# Altium schematic sheet Wemos.SchDoc
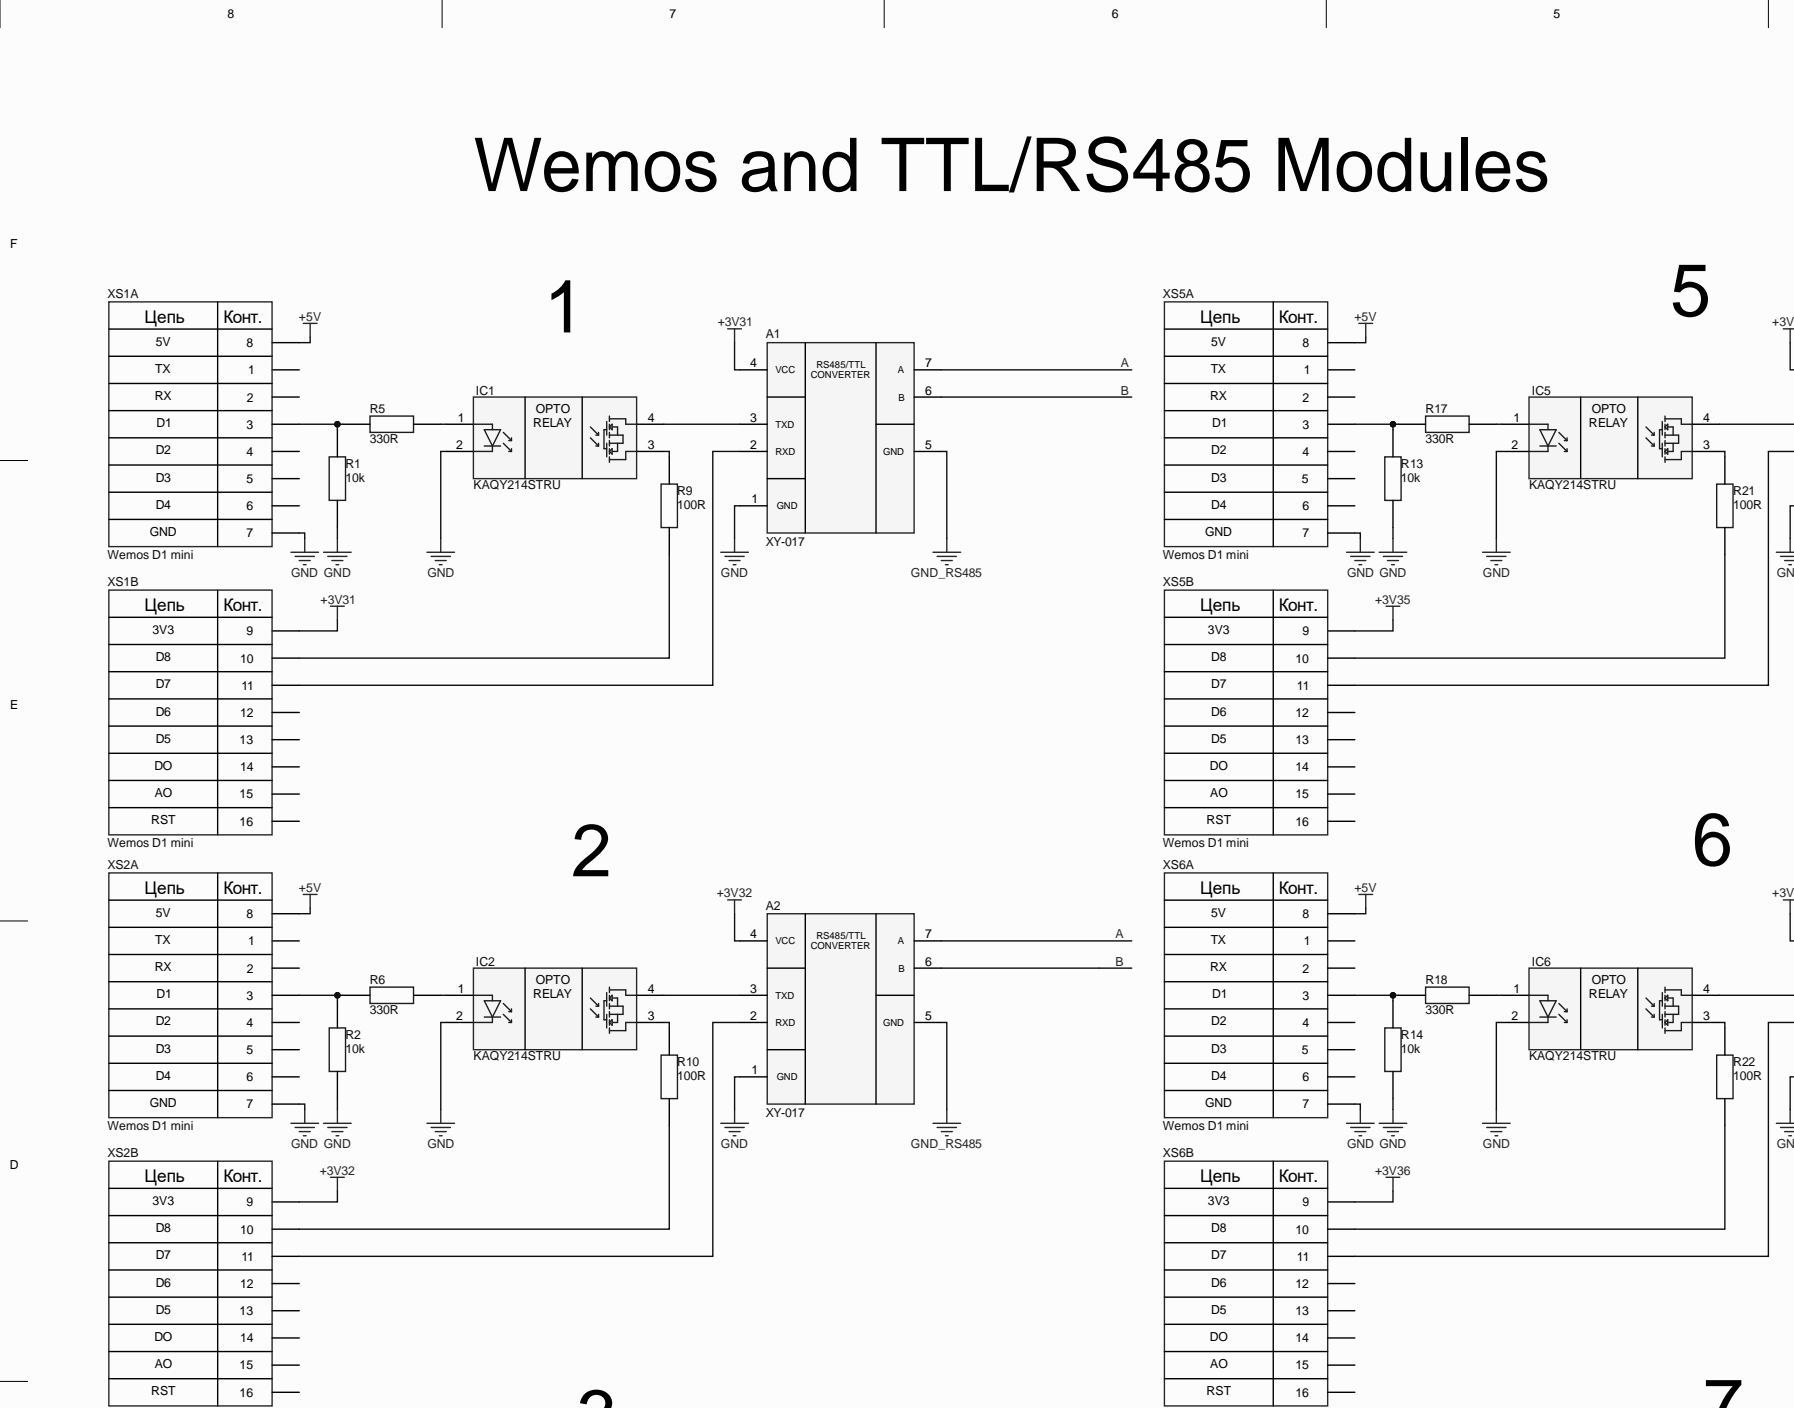
[diagram p0: Wemos.SchDoc, top left]
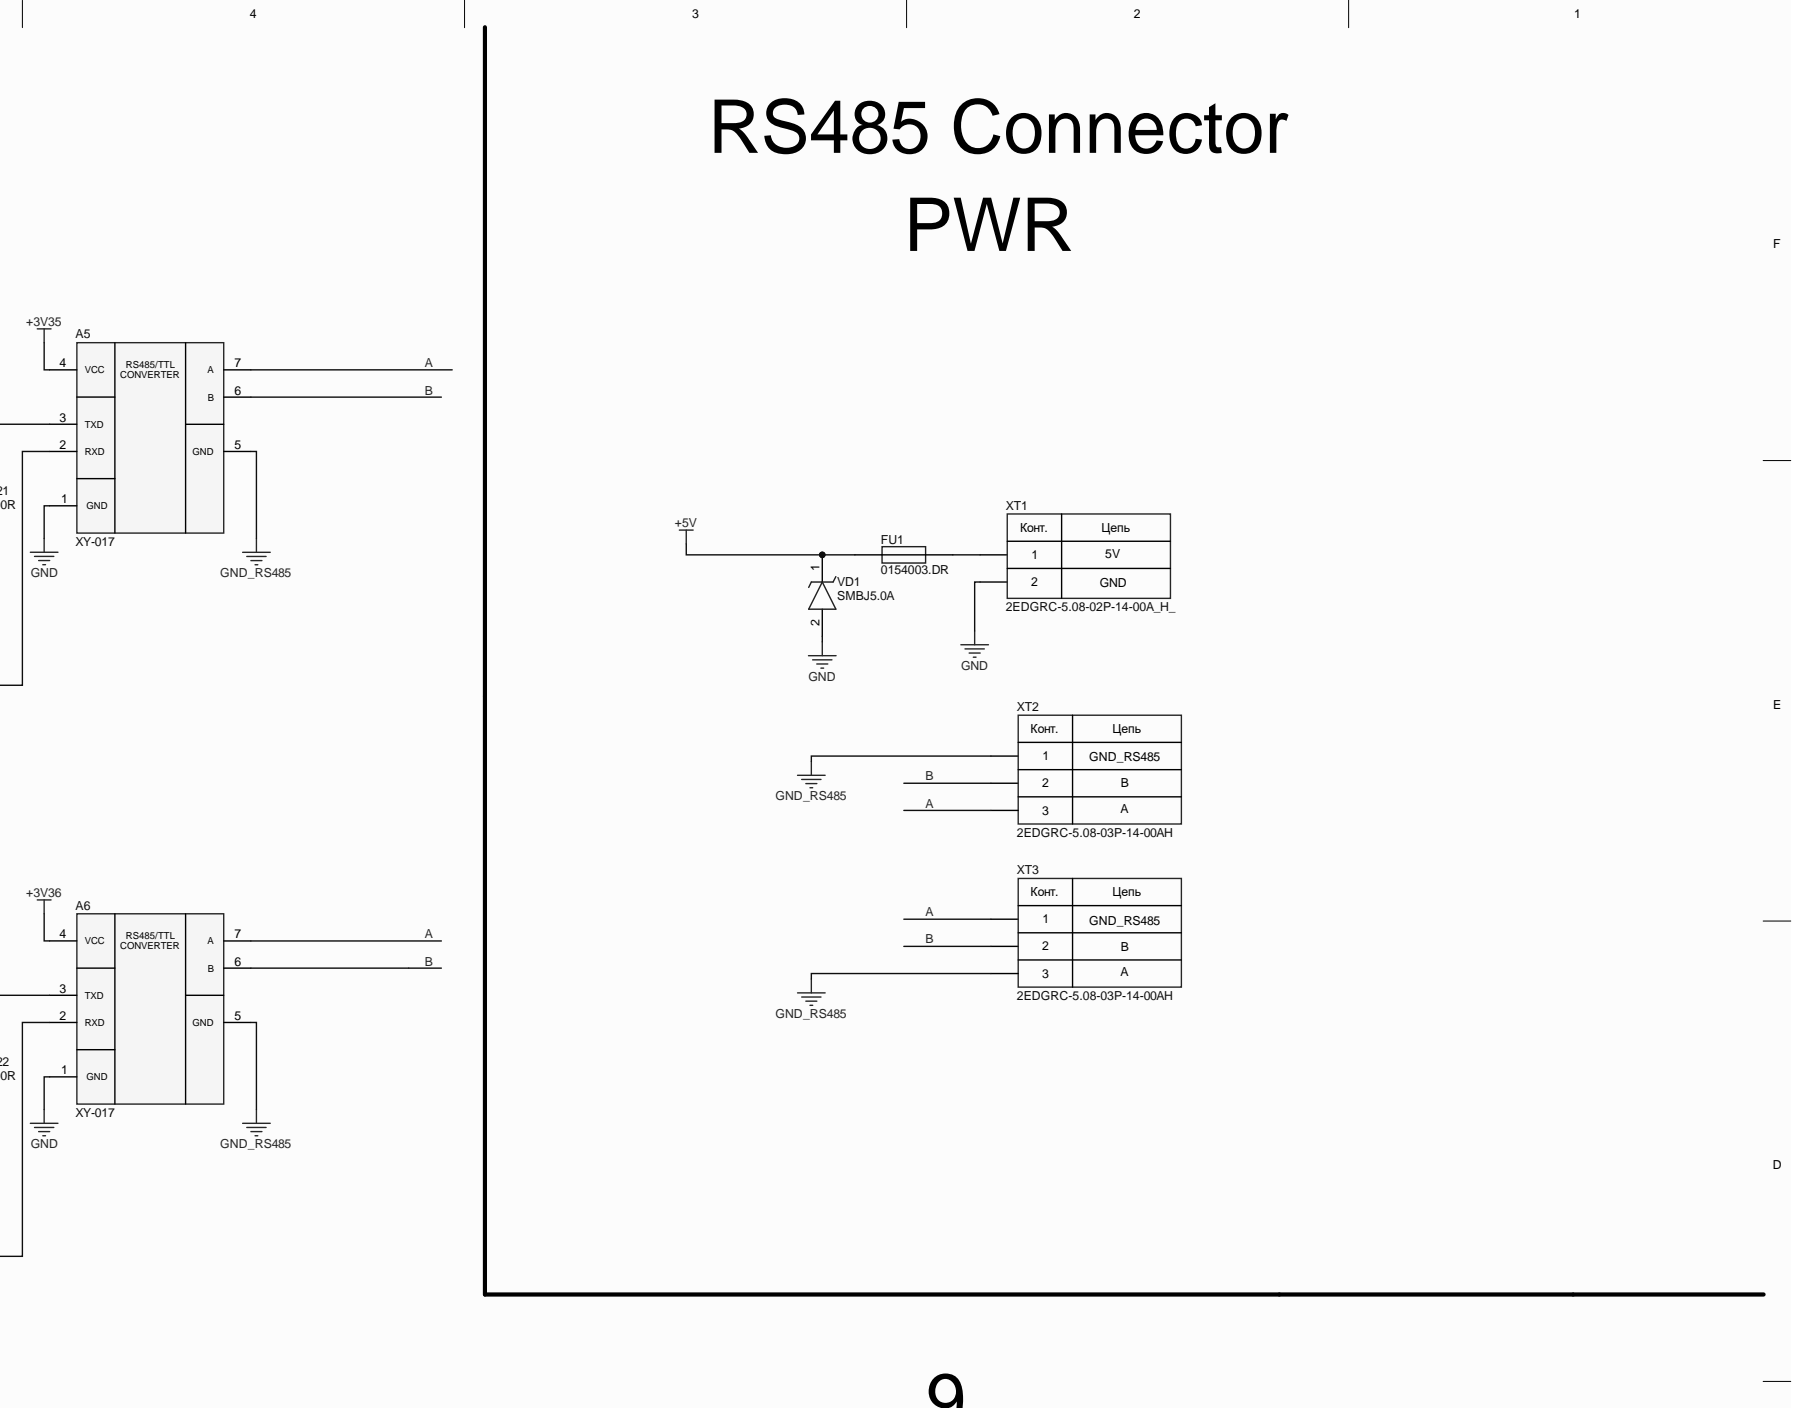
[diagram p1: Wemos.SchDoc, top right]
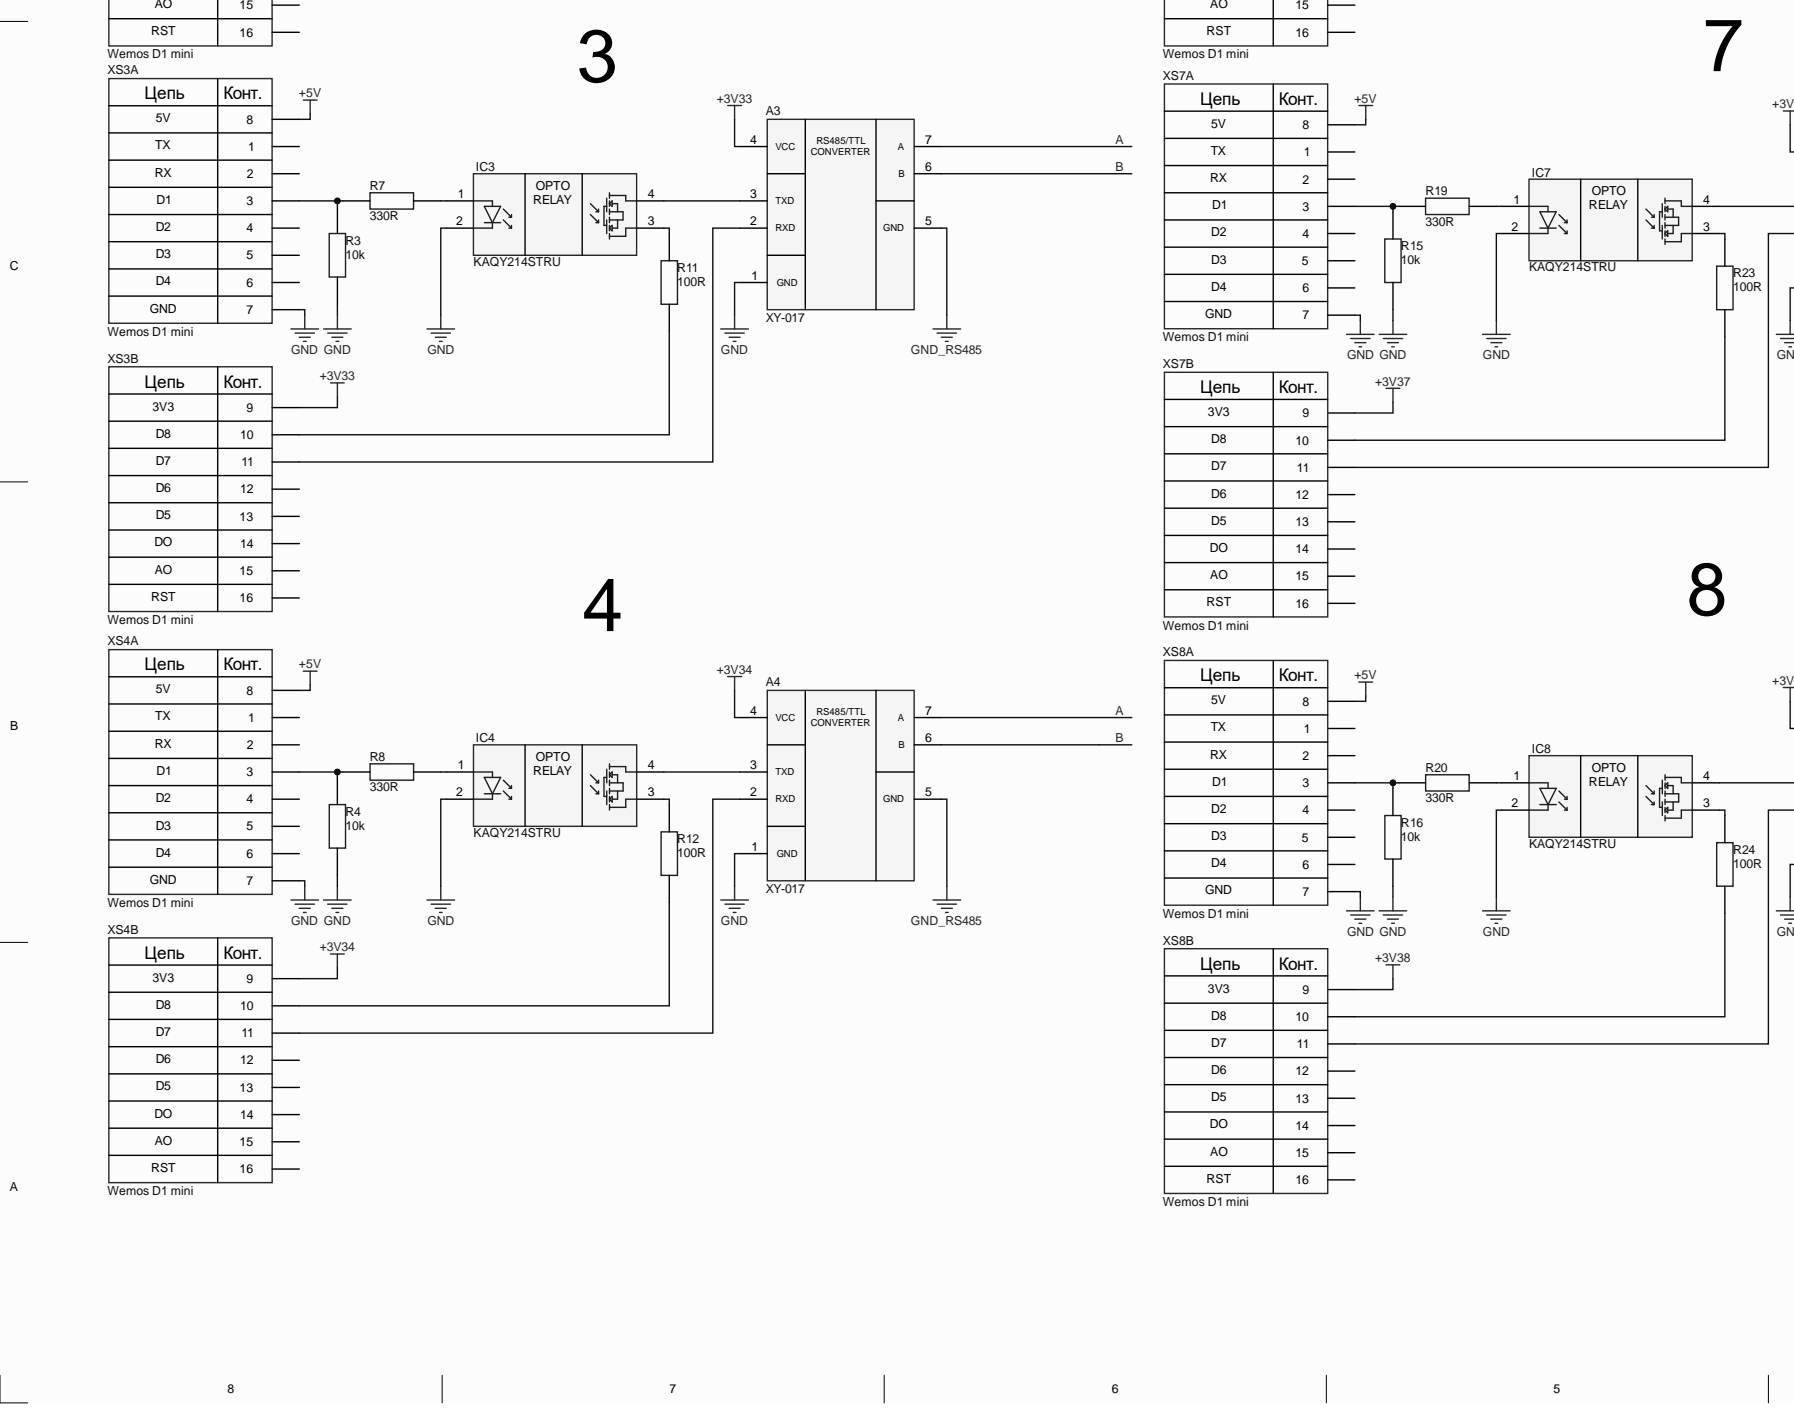
[diagram p2: Wemos.SchDoc, bottom left]
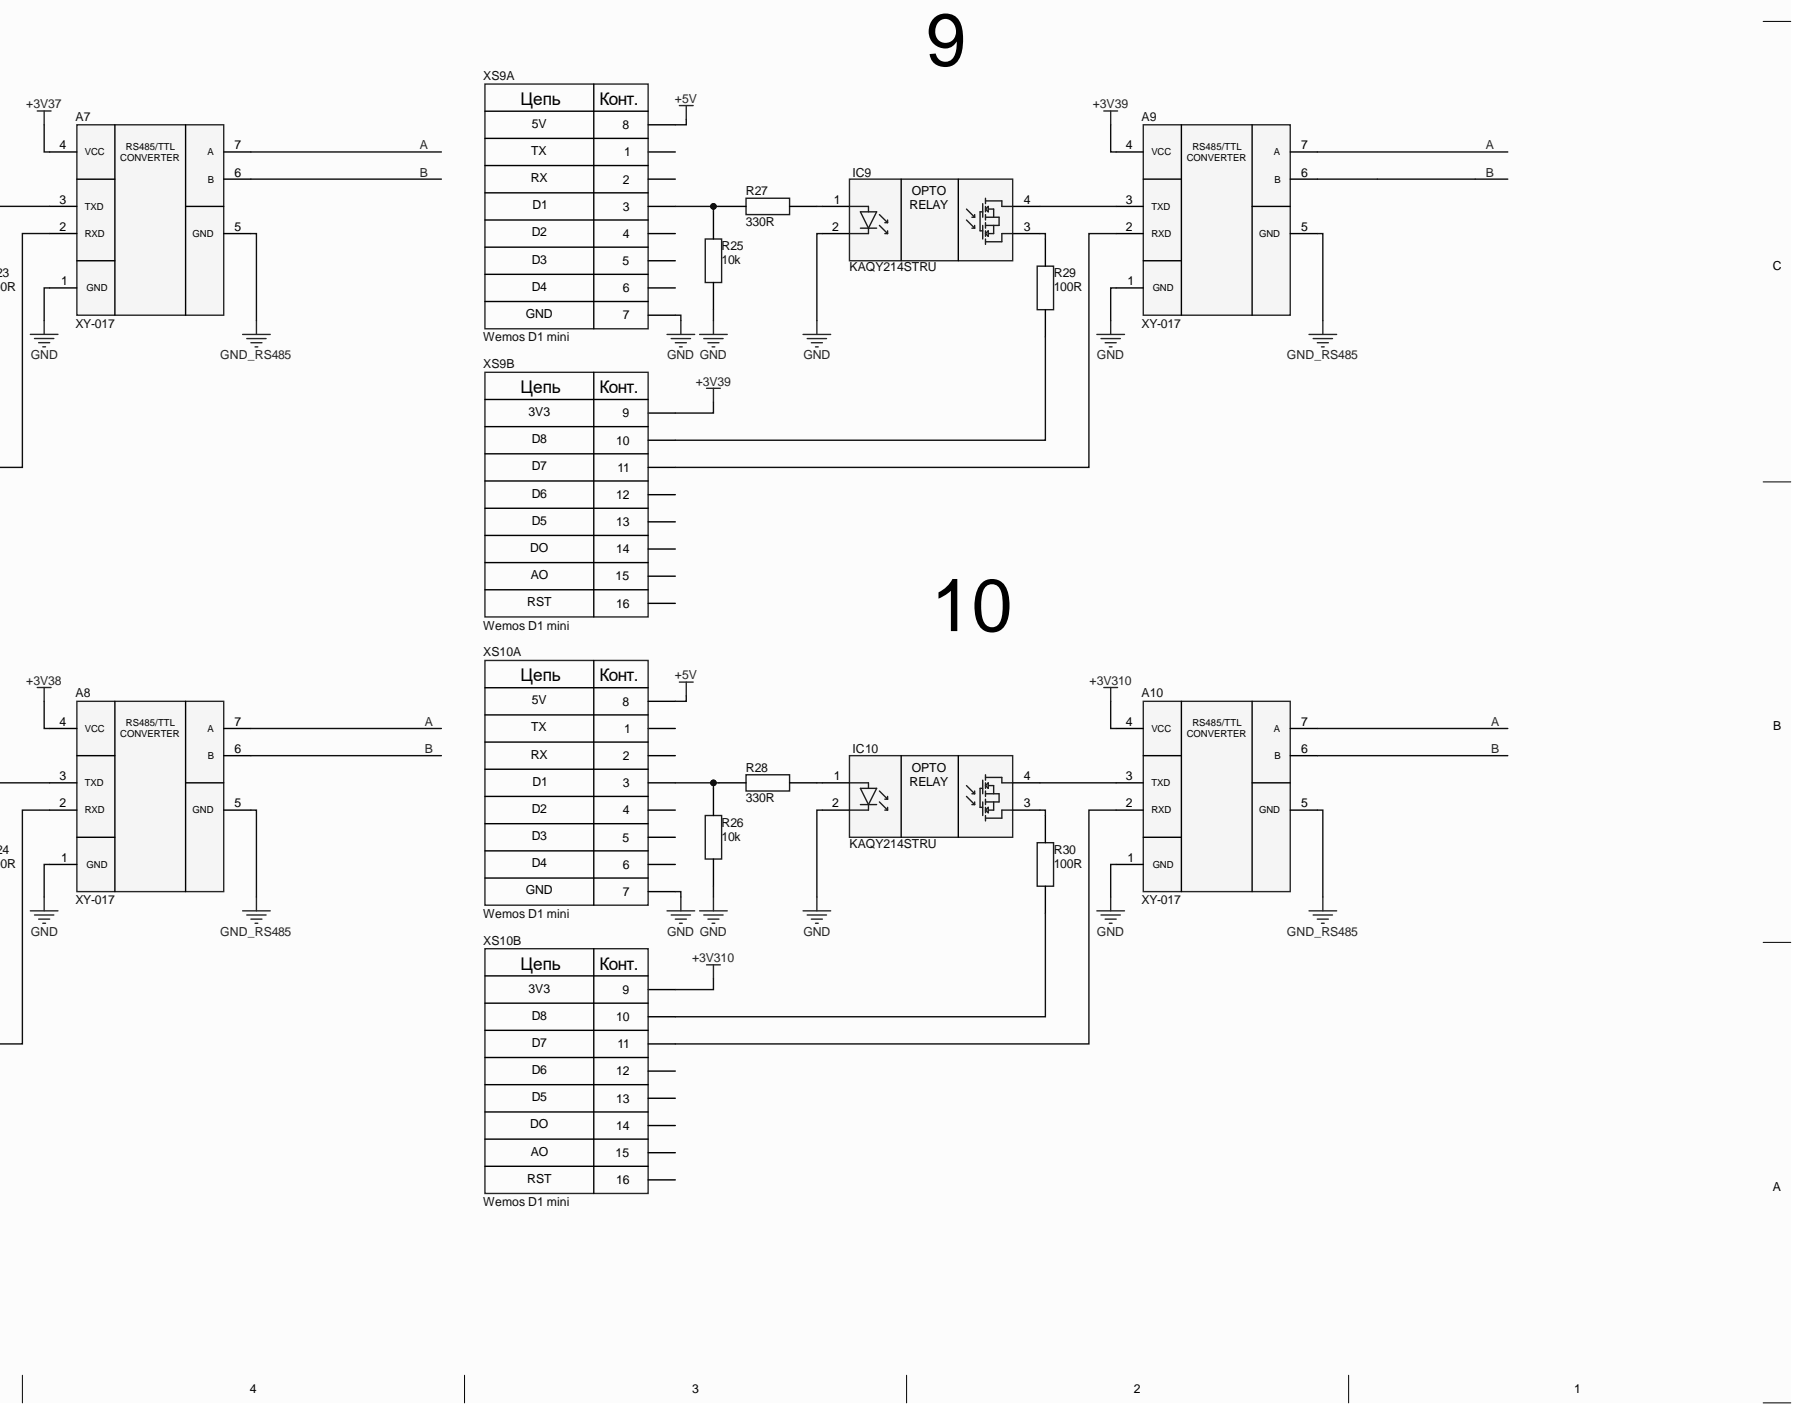
[diagram p3: Wemos.SchDoc, bottom right]

## Parts
A1  XY-017  [XY-017 RS485/TTL]
A2  XY-017  [XY-017 RS485/TTL]
A3  XY-017  [XY-017 RS485/TTL]
A4  XY-017  [XY-017 RS485/TTL]
A5  XY-017  [XY-017 RS485/TTL]
A6  XY-017  [XY-017 RS485/TTL]
A7  XY-017  [XY-017 RS485/TTL]
A8  XY-017  [XY-017 RS485/TTL]
A9  XY-017  [XY-017 RS485/TTL]
A10  XY-017  [XY-017 RS485/TTL]
FU1  0154003.DR  [0154.250DR]
IC1  KAQY214STRU  [SOP-4]
IC2  KAQY214STRU  [SOP-4]
IC3  KAQY214STRU  [SOP-4]
IC4  KAQY214STRU  [SOP-4]
IC5  KAQY214STRU  [SOP-4]
IC6  KAQY214STRU  [SOP-4]
IC7  KAQY214STRU  [SOP-4]
IC8  KAQY214STRU  [SOP-4]
IC9  KAQY214STRU  [SOP-4]
IC10  KAQY214STRU  [SOP-4]
R1  10k  [RES_0603]
R2  10k  [RES_0603]
R3  10k  [RES_0603]
R4  10k  [RES_0603]
R5  330R  [RES_0603]
R6  330R  [RES_0603]
R7  330R  [RES_0603]
R8  330R  [RES_0603]
R9  100R  [RES_0603]
R10  100R  [RES_0603]
R11  100R  [RES_0603]
R12  100R  [RES_0603]
R13  10k  [RES_0603]
R14  10k  [RES_0603]
R15  10k  [RES_0603]
R16  10k  [RES_0603]
R17  330R  [RES_0603]
R18  330R  [RES_0603]
R19  330R  [RES_0603]
R20  330R  [RES_0603]
R21  100R  [RES_0603]
R22  100R  [RES_0603]
R23  100R  [RES_0603]
R24  100R  [RES_0603]
R25  10k  [RES_0603]
R26  10k  [RES_0603]
R27  330R  [RES_0603]
R28  330R  [RES_0603]
R29  100R  [RES_0603]
R30  100R  [RES_0603]
VD1  SMBJ5.0A  [SMB/DOT-214AA]
XS1  Wemos D1 mini  [Wemos D1 mini]  (parts A,B)
XS2  Wemos D1 mini  [Wemos D1 mini]  (parts A,B)
XS3  Wemos D1 mini  [Wemos D1 mini]  (parts A,B)
XS4  Wemos D1 mini  [Wemos D1 mini]  (parts A,B)
XS5  Wemos D1 mini  [Wemos D1 mini]  (parts A,B)
XS6  Wemos D1 mini  [Wemos D1 mini]  (parts A,B)
XS7  Wemos D1 mini  [Wemos D1 mini]  (parts A,B)
XS8  Wemos D1 mini  [Wemos D1 mini]  (parts A,B)
XS9  Wemos D1 mini  [Wemos D1 mini]  (parts A,B)
XS10  Wemos D1 mini  [Wemos D1 mini]  (parts A,B)
XT1  2EDGRC-5.08-02P-14-00A_H_  [2EDGRC-5.0-02P-14-00AH]
XT2  2EDGRС-5.08-03P-14-00AH  [2EDGRС-5.08-03P-14-00AH]
XT3  2EDGRС-5.08-03P-14-00AH  [2EDGRС-5.08-03P-14-00AH]

## Nets
+3V31: A1.4(VCC) XS1.9
+3V32: A2.4(VCC) XS2.9
+3V33: A3.4(VCC) XS3.9
+3V34: A4.4(VCC) XS4.9
+3V35: A5.4(VCC) XS5.9
+3V36: A6.4(VCC) XS6.9
+3V37: A7.4(VCC) XS7.9
+3V38: A8.4(VCC) XS8.9
+3V39: A9.4(VCC) XS9.9
+3V310: A10.4(VCC) XS10.9
+5V: FU1.1 VD1.1 XS1.8 XS2.8 XS3.8 XS4.8 XS5.8 XS6.8 XS7.8 XS8.8 XS9.8 XS10.8
GND: A1.1(GND) A2.1(GND) A3.1(GND) A4.1(GND) A5.1(GND) A6.1(GND) A7.1(GND) A8.1(GND) A9.1(GND) A10.1(GND) IC1.2 IC2.2 IC3.2 IC4.2 IC5.2 IC6.2 IC7.2 IC8.2 IC9.2 IC10.2 R1.1 R2.1 R3.1 R4.1 R13.1 R14.1 R15.1 R16.1 R25.1 R26.1 VD1.2 XS1.7 XS2.7 XS3.7 XS4.7 XS5.7 XS6.7 XS7.7 XS8.7 XS9.7 XS10.7 XT1.2
GND_RS485: A1.5(GND) A2.5(GND) A3.5(GND) A4.5(GND) A5.5(GND) A6.5(GND) A7.5(GND) A8.5(GND) A9.5(GND) A10.5(GND) XT2.1 XT3.3
A: A1.7(A) A2.7(A) A3.7(A) A4.7(A) A5.7(A) A6.7(A) A7.7(A) A8.7(A) A9.7(A) A10.7(A) XT2.3 XT3.1
B: A1.6(B) A2.6(B) A3.6(B) A4.6(B) A5.6(B) A6.6(B) A7.6(B) A8.6(B) A9.6(B) A10.6(B) XT2.2 XT3.2
NetA1_2: A1.2(RXD) XS1.11
NetA1_3: A1.3(TXD) IC1.4
NetA2_2: A2.2(RXD) XS2.11
NetA2_3: A2.3(TXD) IC2.4
NetA3_2: A3.2(RXD) XS3.11
NetA3_3: A3.3(TXD) IC3.4
NetA4_2: A4.2(RXD) XS4.11
NetA4_3: A4.3(TXD) IC4.4
NetA5_2: A5.2(RXD) XS5.11
NetA5_3: A5.3(TXD) IC5.4
NetA6_2: A6.2(RXD) XS6.11
NetA6_3: A6.3(TXD) IC6.4
NetA7_2: A7.2(RXD) XS7.11
NetA7_3: A7.3(TXD) IC7.4
NetA8_2: A8.2(RXD) XS8.11
NetA8_3: A8.3(TXD) IC8.4
NetA9_2: A9.2(RXD) XS9.11
NetA9_3: A9.3(TXD) IC9.4
NetA10_2: A10.2(RXD) XS10.11
NetA10_3: A10.3(TXD) IC10.4
NetFU1_2: FU1.2 XT1.1
NetIC1_1: IC1.1 R5.2
NetIC1_3: IC1.3 R9.2
NetIC2_1: IC2.1 R6.2
NetIC2_3: IC2.3 R10.2
NetIC3_1: IC3.1 R7.2
NetIC3_3: IC3.3 R11.2
NetIC4_1: IC4.1 R8.2
NetIC4_3: IC4.3 R12.2
NetIC5_1: IC5.1 R17.2
NetIC5_3: IC5.3 R21.2
NetIC6_1: IC6.1 R18.2
NetIC6_3: IC6.3 R22.2
NetIC7_1: IC7.1 R19.2
NetIC7_3: IC7.3 R23.2
NetIC8_1: IC8.1 R20.2
NetIC8_3: IC8.3 R24.2
NetIC9_1: IC9.1 R27.2
NetIC9_3: IC9.3 R29.2
NetIC10_1: IC10.1 R28.2
NetIC10_3: IC10.3 R30.2
NetR1_2: R1.2 R5.1 XS1.3
NetR2_2: R2.2 R6.1 XS2.3
NetR3_2: R3.2 R7.1 XS3.3
NetR4_2: R4.2 R8.1 XS4.3
NetR9_1: R9.1 XS1.10
NetR10_1: R10.1 XS2.10
NetR11_1: R11.1 XS3.10
NetR12_1: R12.1 XS4.10
NetR13_2: R13.2 R17.1 XS5.3
NetR14_2: R14.2 R18.1 XS6.3
NetR15_2: R15.2 R19.1 XS7.3
NetR16_2: R16.2 R20.1 XS8.3
NetR21_1: R21.1 XS5.10
NetR22_1: R22.1 XS6.10
NetR23_1: R23.1 XS7.10
NetR24_1: R24.1 XS8.10
NetR25_2: R25.2 R27.1 XS9.3
NetR26_2: R26.2 R28.1 XS10.3
NetR29_1: R29.1 XS9.10
NetR30_1: R30.1 XS10.10

Not connected: XS1 1 2 4 5 6 12 13 14 15 16; XS2 1 2 4 5 6 12 13 14 15 16; XS3 1 2 4 5 6 12 13 14 15 16; XS4 1 2 4 5 6 12 13 14 15 16; XS5 1 2 4 5 6 12 13 14 15 16; XS6 1 2 4 5 6 12 13 14 15 16; XS7 1 2 4 5 6 12 13 14 15 16; XS8 1 2 4 5 6 12 13 14 15 16; XS9 1 2 4 5 6 12 13 14 15 16; XS10 1 2 4 5 6 12 13 14 15 16

## Library data of the parts
A1-A10 = XY-017: RS485 to TTL converter; Current: 0.02A; Voltage: 5.5V; Case(Package): XY-017; Component Kind: Standard; ComponentLink1Description: Datasheet; HelpURL: https://www.chipdip.ru/product0/8021250854; Library Name: KIT_IC.SchLib; Manufacturer_ID: XY-017
FU1 = 0154003.DR: Fuse 3A; Case (Package): 2-SMD, Square End Block with Holder; Component Kind: Standard; ComponentLink1Description: Datasheet; ComponentLink1URL: file:///Z:/Shematic/Datasheet/0154003.pdf; Current: 3A; HelpURL: https://www.chipdip.ru/product0/8010909648; Library Name: KIT_Passive.SchLib; Manufacturer_ID: 0154003.DR; Value: 3A
IC1-IC10 = KAQY214STRU: SOLID STATE OPTO RELAY Normally open; Case (Package): SOP-4; Component Kind: Standard; HelpURL: https://www.promelec.ru/product/346490/; Library Name: KIT_IC.SchLib; Manufacturer_ID: KAQY214STRU
R1-R30 = Res_0603: Resistor; Case (Package): 0603; Component Kind: Standard; ComponentLink1Description: Datasheet; Library Name: KIT_Passive.SchLib
  R1-R4 R13-R16 R25 R26: Value: 10k
  R5-R8 R17-R20 R27 R28: Value: 330R
  R9-R12 R21-R24 R29 R30: Value: 100R
VD1 = SMBJ5.0A: TVS Diode Bidirectional; Case (Package): DO-214; Voltage: 6.4V; Component Kind: Standard; ComponentLink1Description: Datasheet; ComponentLink1URL: file://192.168.16.5/share/Shematic/Datasheet/SMBJ30A.pdf; HelpURL: https://sds.compel.ru/infosheet/YJ/SMBJ30A; Library Name: KIT_Active.SchLib; Manufacturer_ID: SMBJ5.0A
XS1-XS10 = Wemos D1 mini: Connectors; Number of contacts: 16; Pin pitch: 2.54mm; Component Kind: Standard; ComponentLink1Description: Datasheet; Library Name: KIT_Connectors.SchLib; Manufacturer_ID: Wemos D1 mini; Number of rows: 1
XT1 = 2EDGRC-5.08-02P-14-00A_H_: Connectors; Number of contacts: 2; Pin pitch: 5.08; Component Kind: Standard; ComponentLink1Description: Datasheet; ComponentLink1URL: file://192.168.16.5/share/Shematic/Datasheet/2EDGRC-5.08-02P-14-00A_H_.pdf; HelpURL: https://www.chipdip.ru/product0/8452263737; Library Name: KIT_Connectors.SchLib; Manufacturer_ID: 2EDGRC-5.08-02P-14-00A_H_; Number of rows: 1
XT2 XT3 = 2EDGRС-5.08-03P-14-00AH: Connectors; Number of contacts: 3; Pin pitch: 5.08; Component Kind: Standard; ComponentLink1Description: New link; ComponentLink1URL: file://192.168.16.5/share/Shematic/Datasheet/15EDGRC-3.5-03P-14-00AH.pdf; HelpURL: https://www.chipdip.ru/product0/8027122664; Library Name: KIT_Connectors.SchLib; Manufacturer_ID: 2EDGRС-5.08-03P-14-00AH; Number of rows: 1
